# Altium schematic sheet Internal 18_19 1.SchDoc
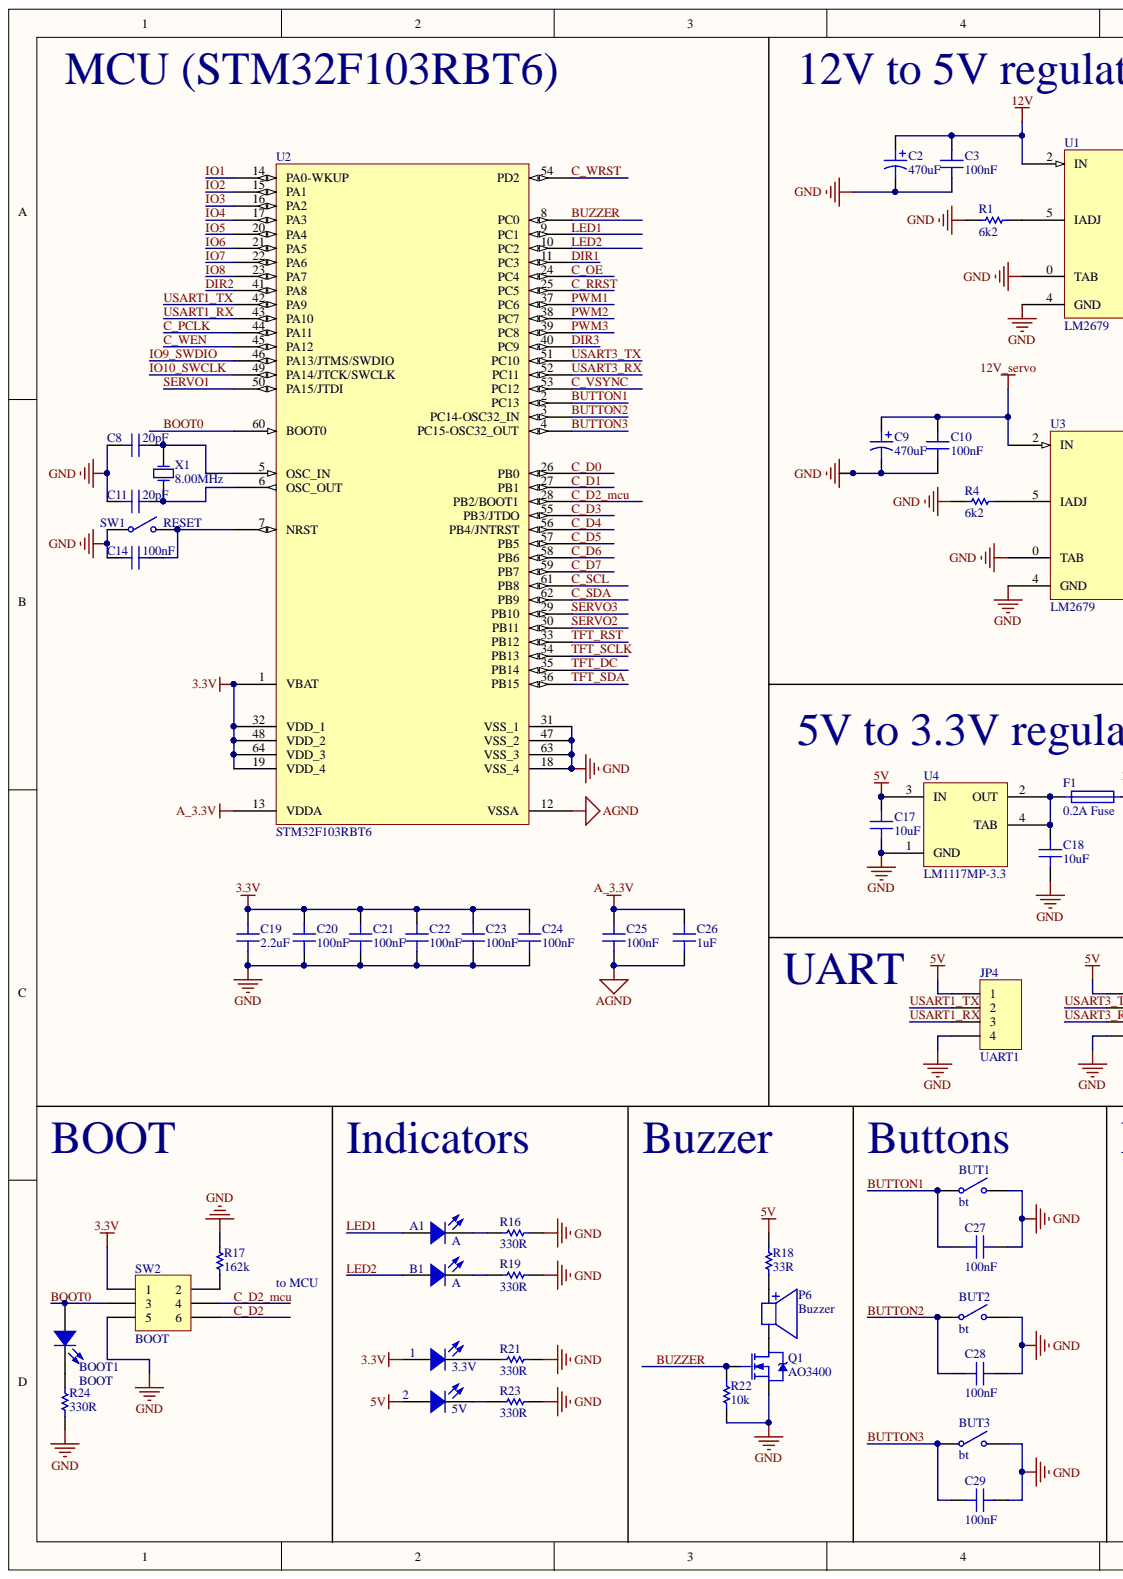
[diagram p0: Internal 18_19 1.SchDoc, left]
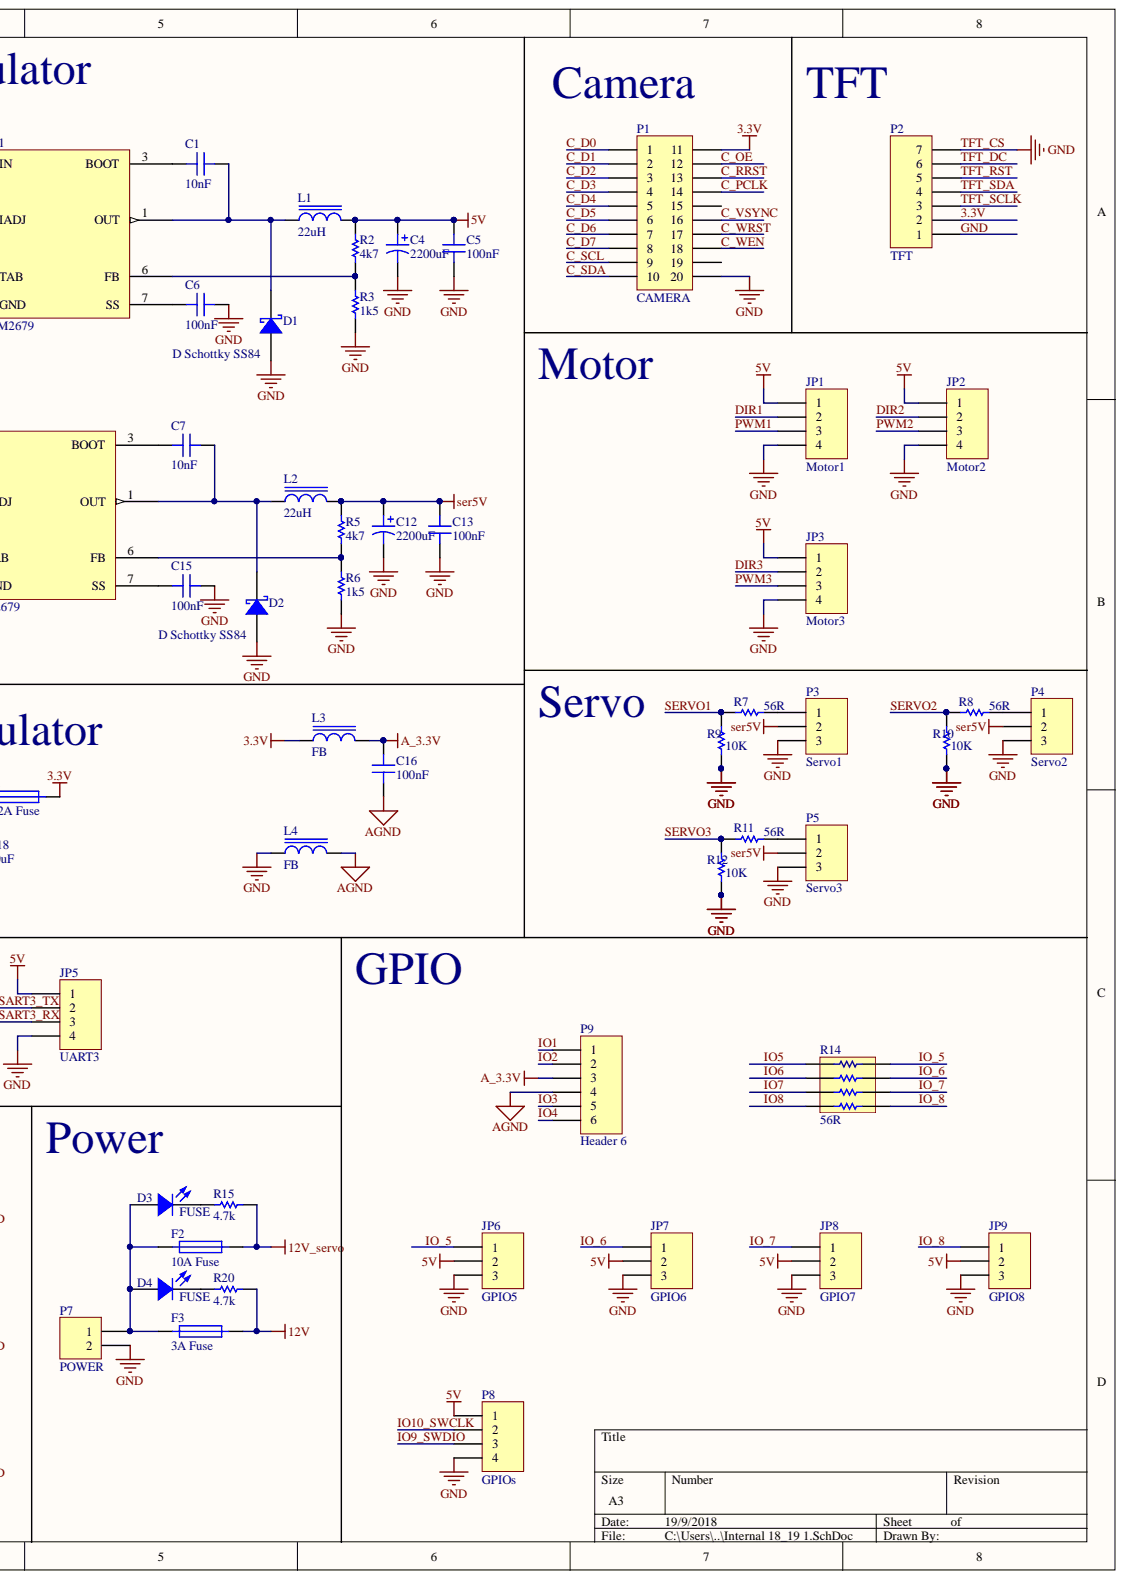
[diagram p1: Internal 18_19 1.SchDoc, right]

## Parts
1  3.3V  [D0805]
2  5V  [D0805]
A1  A  [D0805]
B1  A  [D0805]
BOOT1  BOOT  [D0805]
BUT1  bt  [PUSH SWITCH]
BUT2  bt  [PUSH SWITCH]
BUT3  bt  [PUSH SWITCH]
C1  10nF  [CC2012-0805]
C2  470uF  [CAP10x12]
C3  100nF  [CC2012-0805]
C4  2200uF  [SV-H]
C5  100nF  [CC2012-0805]
C6  100nF  [CC2012-0805]
C7  10nF  [CC2012-0805]
C8  20pF  [CC2012-0805]
C9  470uF  [CAP10x12]
C10  100nF  [CC2012-0805]
C11  20pF  [CC2012-0805]
C12  2200uF  [SV-H]
C13  100nF  [CC2012-0805]
C14  100nF  [CC2012-0805]
C15  100nF  [CC2012-0805]
C16  100nF  [CC3216-1206]
C17  10uF  [CC2012-0805]
C18  10uF  [CC2012-0805]
C19  2.2uF  [CC2012-0805]
C20  100nF  [CC2012-0805]
C21  100nF  [CC2012-0805]
C22  100nF  [CC2012-0805]
C23  100nF  [CC2012-0805]
C24  100nF  [CC2012-0805]
C25  100nF  [CC2012-0805]
C26  1uF  [CC2012-0805]
C27  100nF  [CC2012-0805]
C28  100nF  [CC2012-0805]
C29  100nF  [CC2012-0805]
D1  D Schottky SS84  [SMC]
D2  D Schottky SS84  [SMC]
D3  FUSE  [D0805]
D4  FUSE  [D0805]
F1  0.2A Fuse  [CF3216-1206]
F2  10A Fuse  [FUSE NEW]
F3  3A Fuse  [FUSE NEW]
JP1  Motor1  [LOCKEDHDR-4]
JP2  Motor2  [LOCKEDHDR-4]
JP3  Motor3  [LOCKEDHDR-4]
JP4  UART1  [LOCKEDHDR-4]
JP5  UART3  [LOCKEDHDR-4]
JP6  GPIO5  [LOCKEDHDR-3]
JP7  GPIO6  [LOCKEDHDR-3]
JP8  GPIO7  [LOCKEDHDR-3]
JP9  GPIO8  [LOCKEDHDR-3]
L1  22uH  [CL3225-1210]
L2  22uH  [CL3225-1210]
L3  FB  [CL3225-1210]
L4  FB  [CL3225-1210]
P1  CAMERA  [HDR2X10_CEN]
P2  TFT  [HDR1X7]
P3  Servo1  [LOCKEDHDR-3]
P4  Servo2  [LOCKEDHDR-3]
P5  Servo3  [LOCKEDHDR-3]
P6  Buzzer  [BUZ-9.0]
P7  POWER  [T-CONNECTOR(M)]
P8  GPIOs  [LOCKEDHDR-4]
P9  Header 6  [6410-06]
Q1  AO3400  [SO-G3/Z3.3]
R1  6k2  [CR2012-0805]
R2  4k7  [CR2012-0805]
R3  1k5  [CR2012-0805]
R4  6k2  [CR2012-0805]
R5  4k7  [CR2012-0805]
R6  1k5  [CR2012-0805]
R7  56R  [CR2012-0805]
R8  56R  [CR2012-0805]
R9  10K  [CR2012-0805]
R10  10K  [CR2012-0805]
R11  56R  [CR2012-0805]
R12  10K  [CR2012-0805]
R14  56R  [ARC241-0603x4]
R15  4.7k  [CR2012-0805]
R16  330R  [CR2012-0805]
R17  162k  [CR2010-0804]
R18  33R  [CR2012-0805]
R19  330R  [CR2012-0805]
R20  4.7k  [CR2012-0805]
R21  330R  [CR2012-0805]
R22  10k  [CR2012-0805]
R23  330R  [CR2012-0805]
R24  330R  [CR2010-0804]
SW1  RESET  [PUSH SWITCH]
SW2  BOOT  [POWER SWITCH]
U1  LM2679  [TO-263(7)]
U2  STM32F103RBT6  [LQFP64_N]
U3  LM2679  [TO-263(7)]
U4  LM1117MP-3.3  [MP04A_N]
X1  8.00MHz  [BCY-W2/E4.7]

## Nets
5V: 2.1 C4.1 C5.1 C17.2 JP1.1 JP2.1 JP3.1 JP4.1 JP5.1 JP6.2 JP7.2 JP8.2 JP9.2 L1.2 P8.1 R2.2 R18.2 U4.3(IN)
12V: C2.1 C3.1 F3.2 R20.2 U1.2(IN)
12V_servo: C9.1 C10.1 F2.2 R15.2 U3.2(IN)
A_3.3V: C16.2 C25.2 C26.2 L3.2 P9.3 U2.13(VDDA)
AGND: C16.1 C25.1 C26.1 L4.2 P9.4 U2.12(VSSA)
ser5V: C12.1 C13.1 L2.2 P3.2 P4.2 P5.2 R5.2
3.3V: 1.1 C19.1 C20.2 C21.2 C22.2 C23.2 C24.2 F1.2 L3.1 P1.11 P2.2 SW2.1 U2.1(VBAT) U2.19(VDD_4) U2.32(VDD_1) U2.48(VDD_2) U2.64(VDD_3)
BOOT0: BOOT1.1 SW2.3 U2.60(BOOT0)
BUTTON1: BUT1.1 C27.2 U2.2(PC13)
BUTTON2: BUT2.1 C28.2 U2.3(PC14-OSC32_IN)
BUTTON3: BUT3.1 C29.2 U2.4(PC15-OSC32_OUT)
BUZZER: Q1.1 R22.2 U2.8(PC0)
C_D0: P1.1 U2.26(PB0)
C_D1: P1.2 U2.27(PB1)
C_D2: P1.3 SW2.6
C_D2_mcu: SW2.4 U2.28(PB2/BOOT1)
C_D3: P1.4 U2.55(PB3/JTDO)
C_D4: P1.5 U2.56(PB4/JNTRST)
C_D5: P1.6 U2.57(PB5)
C_D6: P1.7 U2.58(PB6)
C_D7: P1.8 U2.59(PB7)
C_OE: P1.12 U2.24(PC4)
C_PCLK: P1.14 U2.44(PA11)
C_RRST: P1.13 U2.25(PC5)
C_SCL: P1.9 U2.61(PB8)
C_SDA: P1.10 U2.62(PB9)
C_VSYNC: P1.16 U2.53(PC12)
C_WEN: P1.18 U2.45(PA12)
C_WRST: P1.17 U2.54(PD2)
DIR1: JP1.2 U2.11(PC3)
DIR2: JP2.2 U2.41(PA8)
DIR3: JP3.2 U2.40(PC9)
GND: BUT1.2 BUT2.2 BUT3.2 C2.2 C3.2 C4.2 C5.2 C6.2 C8.1 C9.2 C10.2 C11.1 C12.2 C13.2 C14.2 C15.2 C17.1 C18.1 C19.2 C20.1 C21.1 C22.1 C23.1 C24.1 C27.1 C28.1 C29.1 D1.1 D2.1 JP1.4 JP2.4 JP3.4 JP4.4 JP5.4 JP6.3 JP7.3 JP8.3 JP9.3 L4.1 P1.20 P2.1 P2.7 P3.3 P4.3 P5.3 P7.2 P8.4 Q1.2 R1.1 R3.1 R4.1 R6.1 R9.1 R10.1 R12.1 R16.2 R17.1 R19.2 R21.2 R22.1 R23.2 R24.1 SW1.1 SW2.5 U1.0(TAB) U1.4(GND) U2.18(VSS_4) U2.31(VSS_1) U2.47(VSS_2) U2.63(VSS_3) U3.0(TAB) U3.4(GND) U4.1(GND)
IO1: P9.1 U2.14(PA0-WKUP)
IO2: P9.2 U2.15(PA1)
IO3: P9.5 U2.16(PA2)
IO4: P9.6 U2.17(PA3)
IO5: R14.1 U2.20(PA4)
IO6: R14.3 U2.21(PA5)
IO7: R14.5 U2.22(PA6)
IO8: R14.7 U2.23(PA7)
IO9_SWDIO: P8.3 U2.46(PA13/JTMS/SWDIO)
IO10_SWCLK: P8.2 U2.49(PA14/JTCK/SWCLK)
IO_5: JP6.1 R14.2
IO_6: JP7.1 R14.4
IO_7: JP8.1 R14.6
IO_8: JP9.1 R14.8
LED1: A1.1 U2.9(PC1)
LED2: B1.1 U2.10(PC2)
PWM1: JP1.3 U2.37(PC6)
PWM2: JP2.3 U2.38(PC7)
PWM3: JP3.3 U2.39(PC8)
SERVO1: R7.1 R9.2 U2.50(PA15/JTDI)
SERVO2: R8.1 R10.2 U2.30(PB11)
SERVO3: R11.1 R12.2 U2.29(PB10)
TFT_DC: P2.6 U2.35(PB14)
TFT_RST: P2.5 U2.33(PB12)
TFT_SCLK: P2.3 U2.34(PB13)
TFT_SDA: P2.4 U2.36(PB15)
USART1_RX: JP4.3 U2.43(PA10)
USART1_TX: JP4.2 U2.42(PA9)
USART3_RX: JP5.3 U2.52(PC11)
USART3_TX: JP5.2 U2.51(PC10)
Net1_2: 1.2 R21.1
Net2_2: 2.2 R23.1
NetA1_2: A1.2 R16.1
NetB1_2: B1.2 R19.1
NetBOOT1_2: BOOT1.2 R24.2
NetC1_1: C1.1 U1.3(BOOT)
NetC1_2: C1.2 D1.2 L1.1 U1.1(OUT)
NetC6_1: C6.1 U1.7(SS)
NetC7_1: C7.1 U3.3(BOOT)
NetC7_2: C7.2 D2.2 L2.1 U3.1(OUT)
NetC8_2: C8.2 U2.5(OSC_IN) X1.2
NetC11_2: C11.2 U2.6(OSC_OUT) X1.1
NetC14_1: C14.1 SW1.2 U2.7(NRST)
NetC15_1: C15.1 U3.7(SS)
NetC18_2: C18.2 F1.1 U4.2(OUT) U4.4(TAB)
NetD3_1: D3.1 D4.1 F2.1 F3.1 P7.1
NetD3_2: D3.2 R15.1
NetD4_2: D4.2 R20.1
NetP3_1: P3.1 R7.2
NetP4_1: P4.1 R8.2
NetP5_1: P5.1 R11.2
NetP6_1: P6.1 R18.1
NetP6_2: P6.2 Q1.3
NetR1_2: R1.2 U1.5(IADJ)
NetR2_1: R2.1 R3.2 U1.6(FB)
NetR4_2: R4.2 U3.5(IADJ)
NetR5_1: R5.1 R6.2 U3.6(FB)
NetR17_2: R17.2 SW2.2

Not connected: P1 15 19

## Library data of the parts
1 2 A1 B1 BOOT1 D3 D4 = LED: Typical RED GaAs LED; Component Kind: Standard; LatestRevisionDate: 08-Jul-2005; LatestRevisionNote: Mech Layer 1 removed.; Library Name: Robocon_BJT.IntLib; PackageReference: LED-1; Published: 8-Jun-2000; Publisher: Altium Limited
BUT1-BUT3 SW1 = SW-SPST: Single-Pole, Single-Throw Switch; Component Kind: Standard; Library Name: Robocn_connectors.IntLib; Published: 24-Mar-1999; Revision: July-2002: Re-released for DXP Platform.; Publisher: Altium Limited
C1 C3 C5-C8 C10 C11 C13-C29 = Cap Semi: Capacitor (Semiconductor SIM Model); Component Kind: Standard; LatestRevisionDate: 17-Jul-2002; LatestRevisionNote: Re-released for DXP Platform.; Library Name: Robocon_RCL.IntLib; PackageReference: C1206; Published: 8-Jun-2000; Signal Integrity: Cap Semi; Publisher: Altium Limited
C2 C9 = Cap Pol DIP: Polarized Capacitor (Radial); Component Kind: Standard; LatestRevisionDate: 17-Jul-2002; LatestRevisionNote: Re-released for DXP Platform.; Library Name: Robocon_RCL.IntLib; Published: 8-Jun-2000; Publisher: Altium Limited
C4 C12 = Cap Pol SMD: Polarized Capacitor (Axial); Component Kind: Standard; LatestRevisionDate: 17-Jul-2002; LatestRevisionNote: Re-released for DXP Platform.; Library Name: Robocon_RCL.IntLib; Published: 8-Jun-2000; Publisher: Altium Limited
D1 D2 = D Schottky: Schottky Diode; Code - JEDEC: DO-214-AA; Component Kind: Standard; Library Name: Robocon_BJT.IntLib; Published: 8-Jun-2000; Revision: July-2002: Re-released for DXP Platform.; Publisher: Altium Limited
F1-F3 = Fuse: Component Kind: Standard; Description: Fuse; Library Name: Robocon_RCL.IntLib; Published: 8-Jun-2000; Revision: July-2002: Re-released for DXP Platform.; Publisher: Altium Limited
JP1-JP5 P8 = Header 4: Header, 4-Pin; Component Kind: Standard; Library Name: Robocn_connectors.IntLib; Revision: 17-Jul-2002: Re-released for DXP Platform.; Signal Integrity: Connector; Publisher: Altium Limited
JP6-JP9 P3-P5 = Header 3: Header, 3-Pin; Component Kind: Standard; Library Name: Robocn_connectors.IntLib; Revision: 17-Jul-2002: Re-released for DXP Platform.; Signal Integrity: Connector; Publisher: Altium Limited
L1-L4 = Inductor: Value: 10uH; Component Kind: Standard; Description: Inductor; Library Name: Robocon_RCL.IntLib; Published: 8-Jun-2000; Revision: July-2002: Re-released for DXP Platform.; Publisher: Altium Limited
P1 = Header 10X2A: Header, 10-Pin, Dual row; Component Kind: Standard; LatestRevisionDate: 17-Jul-2002; LatestRevisionNote: Re-released for DXP Platform.; Library Name: Miscellaneous Connectors.IntLib; Signal Integrity: Connector; Publisher: Altium Limited
P2 = Header 7: Header, 6-Pin; Component Kind: Standard; LatestRevisionDate: 17-Jul-2002; LatestRevisionNote: Re-released for DXP Platform.; Library Name: Robocn_connectors.IntLib; Publisher: Altium Limited
P7 = Header 2: Header, 2-Pin; Component Kind: Standard; Library Name: Robocn_connectors.IntLib; Revision: 17-Jul-2002: Re-released for DXP Platform.; Signal Integrity: Connector; Publisher: Altium Limited
P9 = Header 6: Header, 6-Pin; Component Kind: Standard; LatestRevisionDate: 17-Jul-2002; LatestRevisionNote: Re-released for DXP Platform.; Library Name: Robocn_connectors.IntLib; Publisher: Altium Limited
Q1 = AO3400: N-Channel MOSFET; Component Kind: Standard; Library Name: Robocon_BJT.IntLib; Published: 8-Jun-2000; Revision: July-2002: Re-released for DXP Platform.; Publisher: Altium Limited
R1-R12 R15-R24 = Res Semi: Semiconductor Resistor; Component Kind: Standard; LatestRevisionDate: 17-Jul-2002; LatestRevisionNote: Re-released for DXP Platform.; Library Name: Robocon_RCL.IntLib; Published: 8-Jun-2000; Signal Integrity: Res Semi; Publisher: Altium Limited
R14 = Res Array: 4x Resistor Array; Component Kind: Standard; LatestRevisionDate: 17-Jul-2002; LatestRevisionNote: Re-released for DXP Platform.; Library Name: Robocon_RCL.IntLib; Published: 8-Jun-2000; Signal Integrity: Res Semi; Publisher: Altium Limited
SW2 = Header 3X2: Header, 3-Pin, Dual row; Component Kind: Standard; Library Name: Robocn_connectors.IntLib; Revision: 17-Jul-2002: Re-released for DXP Platform.; Signal Integrity: Connector; Publisher: Altium Limited
U1 U3 = LM2679: 5A Step-Down Switching Mode Voltage Regulator; Component Kind: Standard; Library Name: Robocon_IC.IntLib
U2 = STM32F103RBT6: STM32 ARM-based 32-bit MCU with 128 Kbytes Flash, 64-pin LQFP, Industrial Temperature; Component Kind: Standard; ComponentLink1Description: Manufacturer Link; ComponentLink1URL: www.st.com/stm32; ComponentLink2Description: Datasheet; ComponentLink2URL: http://www.st.com/stonline/products/literature/ds/13587.pdf; DatasheetVersion: rev6, Mar-2008; Library Name: Robocon_IC.IntLib; PackageDescription: 64-Pin Low-Profile Quad Flat Pack, 10 x 10 mm Body, 0.5 mm Pitch (LQFP64); PackageReference: LQFP64; PackageVersion: Mar-2008; Published: 30-May-2008; Publisher: Altium Limited
U4 = LM1117MP-3.3: 800mA Low-Dropout Linear Regulator; Manufacturer: National Semiconductor; CompType: LDO IC; PackageTopMarking: XYTT*N05A; Code_IPC: SOT223-4; Code_JEDEC: TO-261-AA; Component Kind: Standard; ComponentLink1Description: LM1117 Datasheet; ComponentLink1URL: http://www.national.com/ds/LM/LM1117.pdf; ComponentLink2Description: LM1117 Web Page; ComponentLink2URL: http://www.national.com/pf/LM/LM1117.html; ComponentLink3Description: MP04A Package Page; ComponentLink3URL: http://www.national.com/packaging/folders/mp04a.html; DatasheetVersion: Apr-2006; DigiKeyPartNumber: LM1117MP-3.3CT-ND; Library Name: Robocon_IC.IntLib; PackageDescription: Molded SOT-223, 6.50 x 3.56 x 1.6mm Body, 4 Lead, 2.3mm Pitch; PackageReference: MP04A; PackageVersion: revB, Apr-2003; PartNumber: LM1117MP-3.3; PinCount: 4; Published: 27-Nov-2008; RoHS: O; Publisher: Altium Limited
X1 = XTAL: Crystal Oscillator; Component Kind: Standard; Library Name: Robocon_RCL.IntLib; Published: 8-Jun-2000; Revision: July-2002: Re-released for DXP Platform.; Publisher: Altium Limited
P6 = Buzzer: Component Kind: Standard; Library Name: Robocon_RCL.IntLib
